# Altium schematic sheet Connectors2.SchDoc(Connectors2)
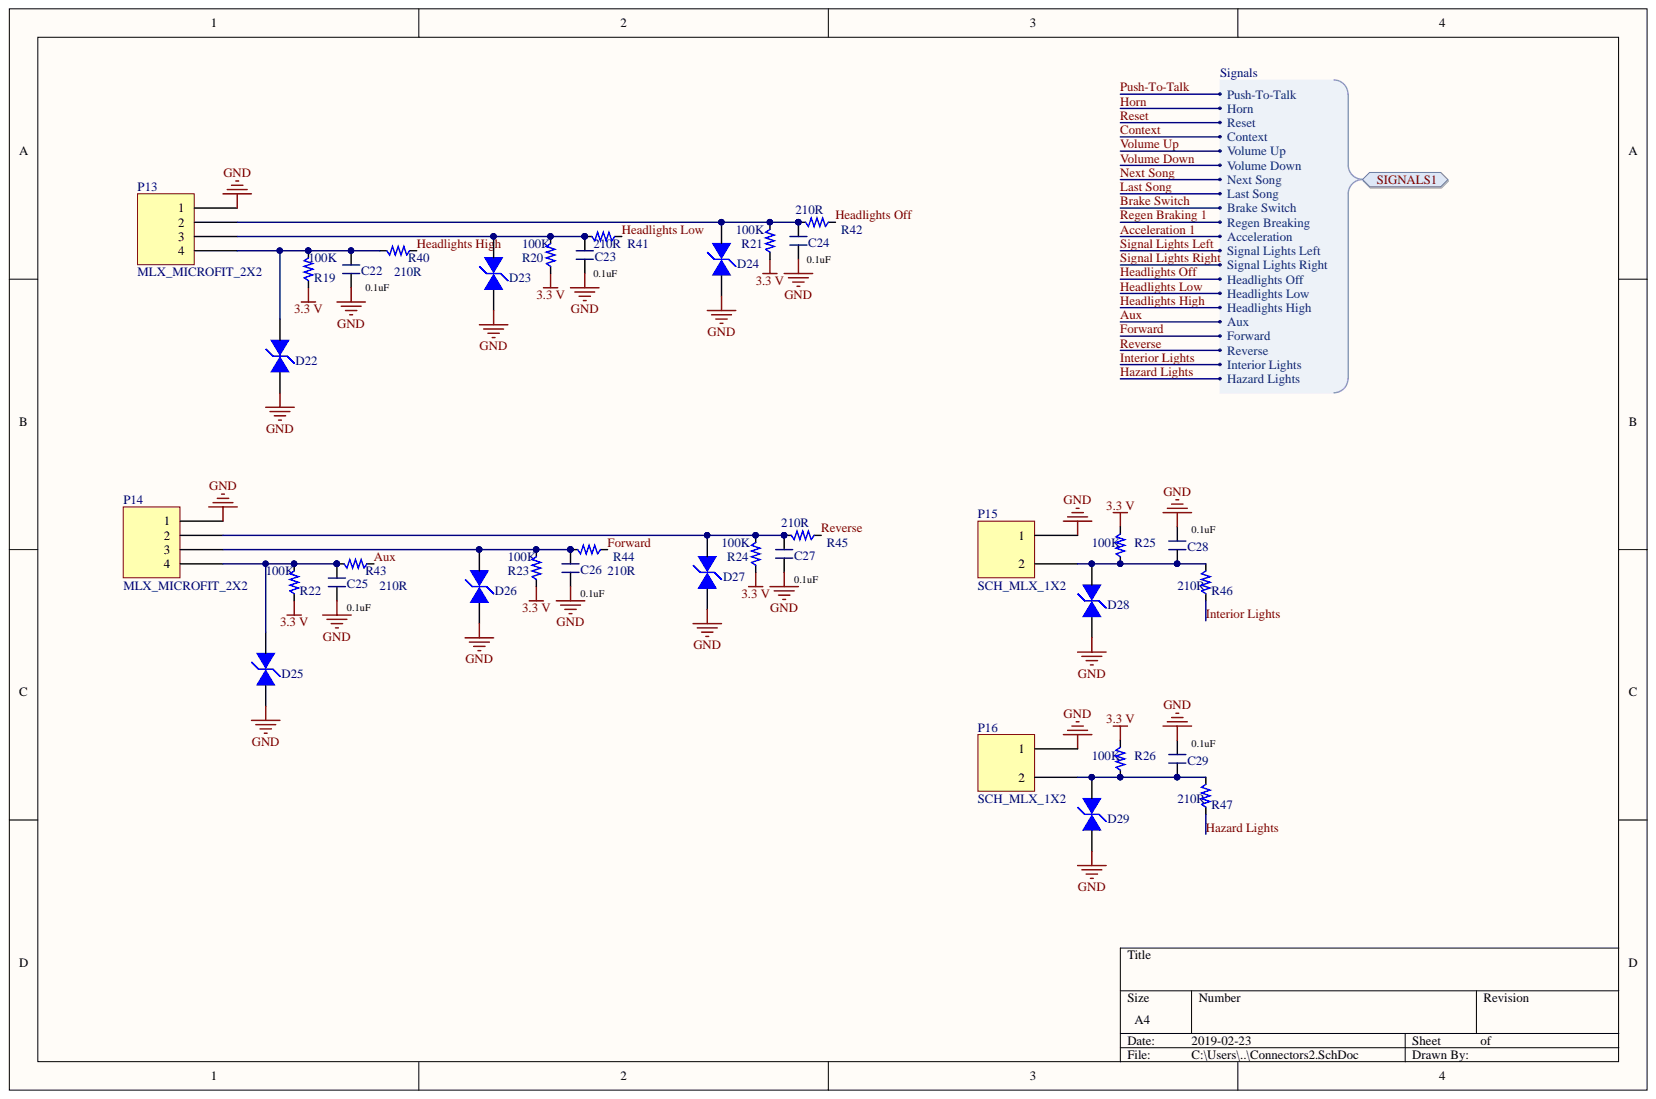
[diagram p0: Connectors2.SchDoc]

## Parts
C22  Capacitance: 0.1uF  [PCB_GENERIC_SMD_0603]  (hidden comment: SCH_GENERIC_CAPACITOR_0603)
C23  Capacitance: 0.1uF  [PCB_GENERIC_SMD_0603]  (hidden comment: SCH_GENERIC_CAPACITOR_0603)
C24  Capacitance: 0.1uF  [PCB_GENERIC_SMD_0603]  (hidden comment: SCH_GENERIC_CAPACITOR_0603)
C25  Capacitance: 0.1uF  [PCB_GENERIC_SMD_0603]  (hidden comment: SCH_GENERIC_CAPACITOR_0603)
C26  Capacitance: 0.1uF  [PCB_GENERIC_SMD_0603]  (hidden comment: SCH_GENERIC_CAPACITOR_0603)
C27  Capacitance: 0.1uF  [PCB_GENERIC_SMD_0603]  (hidden comment: SCH_GENERIC_CAPACITOR_0603)
C28  Capacitance: 0.1uF  [PCB_GENERIC_SMD_0603]  (hidden comment: SCH_GENERIC_CAPACITOR_0603)
C29  Capacitance: 0.1uF  [PCB_GENERIC_SMD_0603]  (hidden comment: SCH_GENERIC_CAPACITOR_0603)
D22  TVS Diode  [TVS Diode]  (value not drawn)
D23  TVS Diode  [TVS Diode]  (value not drawn)
D24  TVS Diode  [TVS Diode]  (value not drawn)
D25  TVS Diode  [TVS Diode]  (value not drawn)
D26  TVS Diode  [TVS Diode]  (value not drawn)
D27  TVS Diode  [TVS Diode]  (value not drawn)
D28  TVS Diode  [TVS Diode]  (value not drawn)
D29  TVS Diode  [TVS Diode]  (value not drawn)
P13  MLX_MICROFIT_2X2  [MLX_MICROFIT_2X2]
P14  MLX_MICROFIT_2X2  [MLX_MICROFIT_2X2]
P15  SCH_MLX_1X2  [PCB_MLX_MICROFIT_1X2]
P16  SCH_MLX_1X2  [PCB_MLX_MICROFIT_1X2]
R19  Resistance: 100K  [PCB_GENERIC_SMD_0603]  (hidden comment: SCH_GENERIC_RESISTOR_0603)
R20  Resistance: 100K  [PCB_GENERIC_SMD_0603]  (hidden comment: SCH_GENERIC_RESISTOR_0603)
R21  Resistance: 100K  [PCB_GENERIC_SMD_0603]  (hidden comment: SCH_GENERIC_RESISTOR_0603)
R22  Resistance: 100K  [PCB_GENERIC_SMD_0603]  (hidden comment: SCH_GENERIC_RESISTOR_0603)
R23  Resistance: 100K  [PCB_GENERIC_SMD_0603]  (hidden comment: SCH_GENERIC_RESISTOR_0603)
R24  Resistance: 100K  [PCB_GENERIC_SMD_0603]  (hidden comment: SCH_GENERIC_RESISTOR_0603)
R25  Resistance: 100K  [PCB_GENERIC_SMD_0603]  (hidden comment: SCH_GENERIC_RESISTOR_0603)
R26  Resistance: 100K  [PCB_GENERIC_SMD_0603]  (hidden comment: SCH_GENERIC_RESISTOR_0603)
R40  Resistance: 210R  [PCB_GENERIC_SMD_0603]  (hidden comment: SCH_GENERIC_RESISTOR_0603)
R41  Resistance: 210R  [PCB_GENERIC_SMD_0603]  (hidden comment: SCH_GENERIC_RESISTOR_0603)
R42  Resistance: 210R  [PCB_GENERIC_SMD_0603]  (hidden comment: SCH_GENERIC_RESISTOR_0603)
R43  Resistance: 210R  [PCB_GENERIC_SMD_0603]  (hidden comment: SCH_GENERIC_RESISTOR_0603)
R44  Resistance: 210R  [PCB_GENERIC_SMD_0603]  (hidden comment: SCH_GENERIC_RESISTOR_0603)
R45  Resistance: 210R  [PCB_GENERIC_SMD_0603]  (hidden comment: SCH_GENERIC_RESISTOR_0603)
R46  Resistance: 210R  [PCB_GENERIC_SMD_0603]  (hidden comment: SCH_GENERIC_RESISTOR_0603)
R47  Resistance: 210R  [PCB_GENERIC_SMD_0603]  (hidden comment: SCH_GENERIC_RESISTOR_0603)

## Ports
SIGNALS1, SIGNALS1.Acceleration, SIGNALS1.Aux, SIGNALS1.Brake Switch, SIGNALS1.Context, SIGNALS1.Forward, SIGNALS1.Hazard Lights, SIGNALS1.Headlights High, SIGNALS1.Headlights Low, SIGNALS1.Headlights Off, SIGNALS1.Horn, SIGNALS1.Interior Lights, SIGNALS1.Last Song, SIGNALS1.Next Song, SIGNALS1.Push-To-Talk, SIGNALS1.Regen Breaking, SIGNALS1.Reset, SIGNALS1.Reverse, SIGNALS1.Signal Lights Left, SIGNALS1.Signal Lights Right, SIGNALS1.Volume Down, SIGNALS1.Volume Up

## Nets
3.3 V: R19.1 R20.1 R21.1 R22.1 R23.1 R24.1 R25.2 R26.2
GND: C22.1 C23.1 C24.1 C25.1 C26.1 C27.1 C28.2 C29.2 D22.2 D23.2 D24.2 D25.2 D26.2 D27.2 D28.2 D29.2 P13.1 P14.1 P15.1 P16.1
Aux: R43.1 ; port SIGNALS1
Forward: R44.1 ; port SIGNALS1
Hazard Lights: R47.1 ; port SIGNALS1
Headlights High: R40.1 ; port SIGNALS1
Headlights Low: R41.1 ; port SIGNALS1
Headlights Off: R42.1 ; port SIGNALS1
Interior Lights: R46.1 ; port SIGNALS1
Reverse: R45.1 ; port SIGNALS1
NetC22_2: C22.2 D22.1 P13.4 R19.2 R40.2
NetC23_2: C23.2 D23.1 P13.3 R20.2 R41.2
NetC24_2: C24.2 D24.1 P13.2 R21.2 R42.2
NetC25_2: C25.2 D25.1 P14.4 R22.2 R43.2
NetC26_2: C26.2 D26.1 P14.3 R23.2 R44.2
NetC27_2: C27.2 D27.1 P14.2 R24.2 R45.2
NetC28_1: C28.1 D28.1 P15.2 R25.1 R46.2
NetC29_1: C29.1 D29.1 P16.2 R26.1 R47.2
Acceleration 1: ; port SIGNALS1
Brake Switch: ; port SIGNALS1
Context: ; port SIGNALS1
Horn: ; port SIGNALS1
Last Song: ; port SIGNALS1
Next Song: ; port SIGNALS1
Push-To-Talk: ; port SIGNALS1
Regen Braking 1: ; port SIGNALS1
Reset: ; port SIGNALS1
Signal Lights Left: ; port SIGNALS1
Signal Lights Right: ; port SIGNALS1
Volume Down: ; port SIGNALS1
Volume Up: ; port SIGNALS1

## Library data of the parts
C22-C29 = SCH_GENERIC_CAPACITOR_0603: Generic SMD capacitor 0603; Tolerance: *%; Library Name: Generic 0603 Capacitor.SchLib; Component Kind: Standard
D22-D29 = TVS Diode: Manufacturer ID: CDSOD323-T03SC; Manufacturer: Bourns; Library Name: TVS Diode.SchLib; Component Kind: Standard
R19-R26 R40-R47 = SCH_GENERIC_RESISTOR_0603: Generic SMD resistor 0603; Library Name: Generic 0603 Resistor.SchLib; Component Kind: Standard
P13 P14 = MLX_MICROFIT_2X2: Library Name: Molex Microfit 3.0 2x2.SchLib; Component Kind: Standard
P15 P16 = SCH_MLX_1X2: Library Name: Molex Microfit 3.0 1x2.SchLib; Component Kind: Standard
